# Altium schematic sheet EVK-NORA-W4_SCHEM_0001_Power.SchDoc
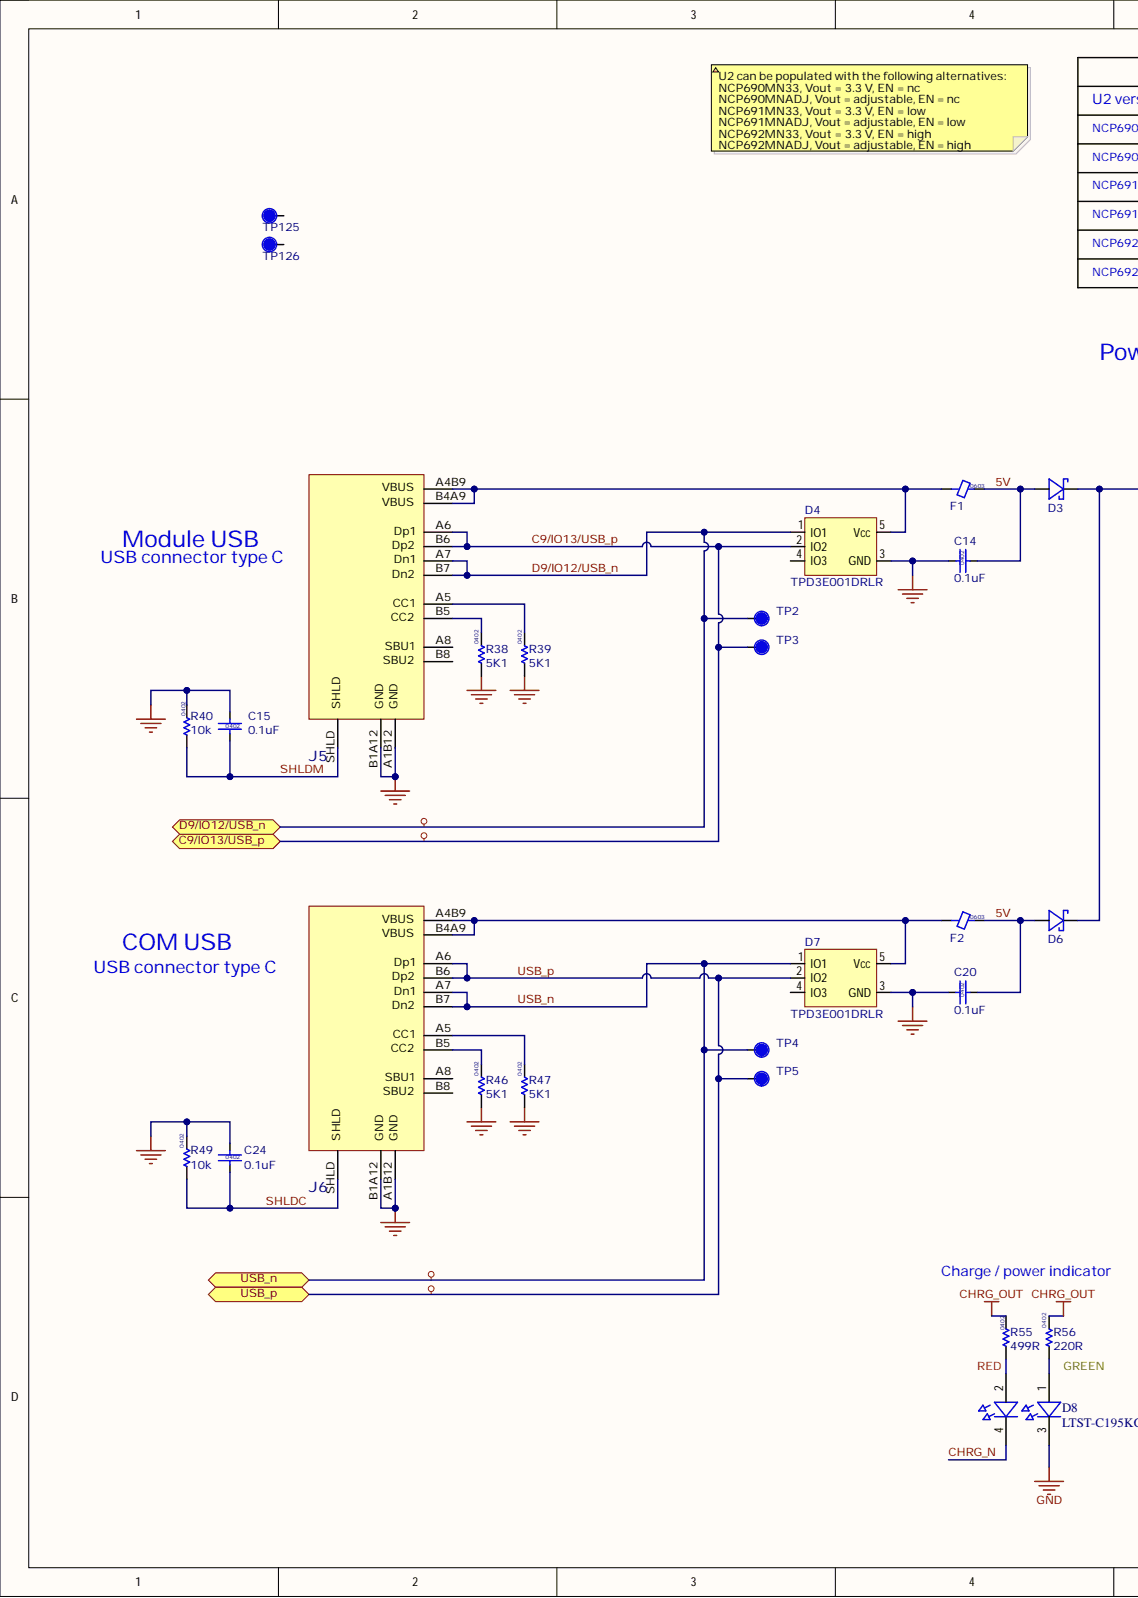
[diagram p0: EVK-NORA-W4_SCHEM_0001_Power.SchDoc, left]
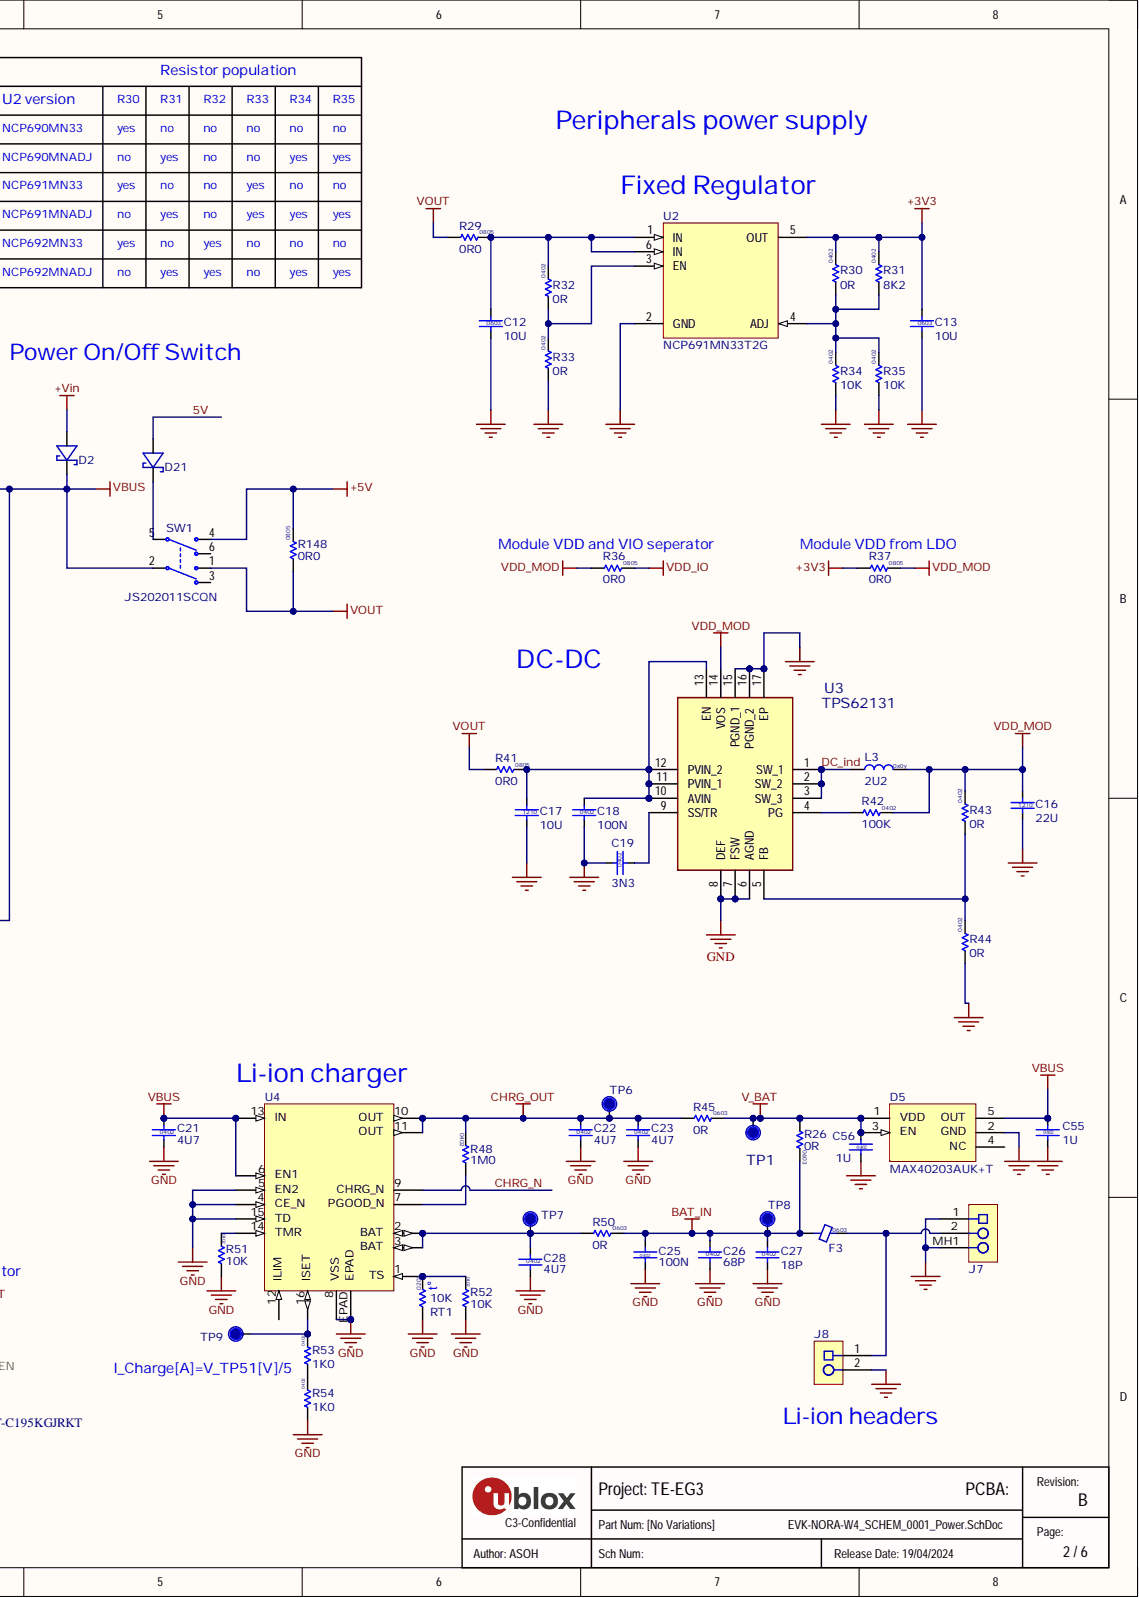
[diagram p1: EVK-NORA-W4_SCHEM_0001_Power.SchDoc, right]

## Parts
C12  value 10U  Size: 0603  [CAP_0603_1750Lx950Wx850H_600x1000Pad_900G_FLX]  (hidden comment: UBXH01-000031010U)
C13  value 10U  Size: 0603  [CAP_0603_1750Lx950Wx850H_600x1000Pad_900G_FLX]  (hidden comment: UBXH01-000031010U)
C14  value 0.1uF  Size: 0402  [Cap 0402 HD]  (hidden comment: 120-000160.1uF)
C15  value 0.1uF  Size: 0402  [Cap 0402 HD]  (hidden comment: 120-000160.1uF)
C16  value 22U  Size: 1210  [CAP_1210_3400Lx2700Wx1350H_900x2700Pad_1900G_FLX]  (hidden comment: UBXH01-300100522U)
C17  value 10U  Size: 1210  [CAP_1210_3400Lx2700Wx1350H_900x2700Pad_1900G_FLX]  (hidden comment: UBXH01-300100410U)
C18  value 100N  Size: 0402  [Cap_0402_1100Lx600Wx600H_600x600Pad_350G_FLX]  (hidden comment: UBXH01-0000204100N)
C19  value 3N3  Size: 0402  [Cap_0402_1100Lx600Wx600H_600x600Pad_350G_FLX]  (hidden comment: UBXH01-00230193N3)
C20  value 0.1uF  Size: 0402  [Cap 0402 HD]  (hidden comment: 120-000160.1uF)
C21  value 4U7  Size: 0402  [Cap 0402 HD]  (hidden comment: UBXH01-00002434U7)
C22  value 4U7  Size: 0402  [Cap 0402 HD]  (hidden comment: UBXH01-00002434U7)
C23  value 4U7  Size: 0402  [Cap 0402 HD]  (hidden comment: UBXH01-00002434U7)
C24  value 0.1uF  Size: 0402  [Cap 0402 HD]  (hidden comment: 120-000160.1uF)
C25  value 100N  Size: 0402  [Cap 0402 HD]  (hidden comment: UBXH01-2021002100N)
C26  value 68P  Size: 0402  [Cap 0402 HD]  (hidden comment: UBXH01-000402468P)
C27  value 18P  Size: 0402  [Cap_0402_1100Lx600Wx600H_600x600Pad_350G_FLX]  (hidden comment: UBXH01-000401718P)
C28  value 4U7  Size: 0402  [Cap 0402 HD]  (hidden comment: UBXH01-00002434U7)
C55  value 1U  Size: 0402  [Cap 0402 HD]  (hidden comment: UBXH01-00002481U)
C56  value 1U  Size: 0402  [Cap 0402 HD]  (hidden comment: UBXH01-00002481U)
D2  110-00005  [SOD-123F_U]  (value not drawn)
D3  110-00005  [SOD-123F_U]  (value not drawn)
D4  Manufacturer P/N: TPD3E001DRLR  [SOT-553]  (hidden comment: 110-00018)
D5  value MAX40203AUK+T  Manufacturer P/N: MAX40203AUK+T  [SOT-23-5_2]  (hidden comment: UBXH12-0000539)
D6  110-00005  [SOD-123F_U]  (value not drawn)
D7  Manufacturer P/N: TPD3E001DRLR  [SOT-553]  (hidden comment: 110-00018)
D8  LTST-C195KGJRKT  [LTSTC195KGJRKT]
D21  110-00005  [SOD-123F_U]  (value not drawn)
F1  Size: 0603  [IND_0603_1750Lx950Wx1050H_600x800Pad_800G_FLX]  (hidden comment: UBXH08-0000001)
F2  Size: 0603  [IND_0603_1750Lx950Wx1050H_600x800Pad_800G_FLX]  (hidden comment: UBXH08-0000001)
F3  Size: 0603  [IND_0603_1750Lx950Wx1050H_600x800Pad_800G_FLX]  (hidden comment: UBXH08-0000001)
J5  USB4105-GF-A  [USB4105-GF-A]  (value not drawn)
J6  USB4105-GF-A  [USB4105-GF-A]  (value not drawn)
J7  UBXH04-0000791  [B2B-PH-SM4-TB]  (value not drawn)
J8  UBXH04-0000026  [HDR-0.1P-2PIN-TH]  (value not drawn)
L3  value 2U2  Size: 0x0y  [IND_0603_1800Lx1000Wx1000H_600x1000Pad_650G_FLX]  (hidden comment: UBXH09-00003302U2)
R26  value 0R  Size: 0603  [RES_0603_1700Lx950Wx600H_600x1000Pad_800G_FLX]  (hidden comment: UBXH18-00030010R)
R29  0R0  Size: 0805  [RES 0805_2150Lx1400Wx650H_800x1300Pad_1000G_FLX]
R30  value 0R  Size: 0402  [RES 0402 HD]  (hidden comment: UBXH18-00030020R)
R31  value 8K2  Size: 0402  [RES_0402_1100Lx600Wx400H_500x600Pad_400G_FLX]  (hidden comment: UBXH18-00001388K2)
R32  value 0R  Size: 0402  [RES 0402 HD]  (hidden comment: UBXH18-00030020R)
R33  value 0R  Size: 0402  [RES 0402 HD]  (hidden comment: UBXH18-00030020R)
R34  value 10K  Size: 0402  [RES 0402 HD]  (hidden comment: UBXH18-000006510K)
R35  value 10K  Size: 0402  [RES 0402 HD]  (hidden comment: UBXH18-000006510K)
R36  0R0  Size: 0805  [RES 0805_2150Lx1400Wx650H_800x1300Pad_1000G_FLX]
R37  0R0  Size: 0805  [RES 0805_2150Lx1400Wx650H_800x1300Pad_1000G_FLX]
R38  value 5K1  Size: 0402  [RES 0402 HD]  (hidden comment: UBXH18-00080665K1)
R39  value 5K1  Size: 0402  [RES 0402 HD]  (hidden comment: UBXH18-00080665K1)
R40  value 10k  Size: 0402  [RES 0402 HD]  (hidden comment: 180-0002410k)
R41  0R0  Size: 0805  [RES 0805_2150Lx1400Wx650H_800x1300Pad_1000G_FLX]
R42  value 100K  Size: 0402  [RES 0402 HD]  (hidden comment: UBXH18-0000005100K)
R43  value 0R  Size: 0402  [RES 0402 HD]  (hidden comment: UBXH18-00030020R)
R44  value 0R  Size: 0402  [RES 0402 HD]  (hidden comment: UBXH18-00030020R)
R45  value 0R  Size: 0603  [RES_0603_1700Lx950Wx600H_600x1000Pad_800G_FLX]  (hidden comment: UBXH18-00030010R)
R46  value 5K1  Size: 0402  [RES 0402 HD]  (hidden comment: UBXH18-00080665K1)
R47  value 5K1  Size: 0402  [RES 0402 HD]  (hidden comment: UBXH18-00080665K1)
R48  value 1M0  Size: 0402  [RES 0402 HD]  (hidden comment: UBXH18-00081211M0)
R49  value 10k  Size: 0402  [RES 0402 HD]  (hidden comment: 180-0002410k)
R50  value 0R  Size: 0603  [RES_0603_1700Lx950Wx600H_600x1000Pad_800G_FLX]  (hidden comment: UBXH18-00030010R)
R51  value 10K  Size: 0402  [RES 0402 HD]  (hidden comment: UBXH18-000006510K)
R52  value 10K  Size: 0402  [RES 0402 HD]  (hidden comment: UBXH18-000006510K)
R53  value 1K0  Size: 0402  [RES 0402 HD]  (hidden comment: UBXH18-00080491K0)
R54  value 1K0  Size: 0402  [RES 0402 HD]  (hidden comment: UBXH18-00080491K0)
R55  value 499R  Size: 0402  [RES 0402 HD]  (hidden comment: UBXH18-0000269499R)
R56  value 220R  Size: 0402  [RES 0402 HD]  (hidden comment: UBXH18-0008033220R)
R148  0R0  Size: 0805  [RES 0805_2150Lx1400Wx650H_800x1300Pad_1000G_FLX]
RT1  value 10K  Size: 0201  [RES 0201]  (hidden comment: Res Thermal)
SW1  value JS202011SCQN  Manufacturer P/N: JS202011SCQN  [SW_Slide_DPDT_JS202011SCQN]  (hidden comment: UBXH19-0000050)
TP1  TP  [TP10R]
TP2  TP  [TP10R]  (no BOM)
TP3  TP  [TP10R]  (no BOM)
TP4  TP  [TP10R]  (no BOM)
TP5  TP  [TP10R]  (no BOM)
TP6  TP  [TP10R]  (no BOM)
TP7  TP  [TP10R]  (no BOM)
TP8  TP  [TP10R]  (no BOM)
TP9  TP  [TP10R]  (no BOM)
TP125  TP  [TP10R]  (no BOM)
TP126  TP  [TP10R]  (no BOM)
U2  NCP691MN33T2G  Part Type: Regulator  [DFN-PSON.1P3X3-7pin_HS-7N]
U3  TPS62131  [QFN50P300X300X100-17N-D]
U4  UBXH05-0000185  [QFN0.5P3x3-16Pin_W-Pad]  (value not drawn)

## Ports
C9/IO13/USB_p, D9/IO12/USB_n, USB_n, USB_p

## Nets
+3V3: C13.1 R30.2 R31.2 R37.1 U2.5(OUT)
+Vin: D2.A
BAT_IN: C25.2 C26.1 C27.2 F3.1 R26.2 R50.2 TP8.1
CHRG_OUT: C22.1 C23.1 R45.1 R48.2 R55.2 R56.2 TP6.1 U4.10(OUT) U4.11(OUT)
GND: C12.2 C13.2 C14.1 C15.1 C16.2 C17.2 C18.2 C19.1 C20.1 C21.2 C22.2 C23.2 C24.1 C25.1 C26.2 C27.1 C28.2 C55.2 C56.2 D4.3(GND) D5.2(GND) D7.3(GND) D8.3 J5.A1B12(GND) J5.B1A12(GND) J6.A1B12(GND) J6.B1A12(GND) J7.1 J7.MH1 J8.2 R33.1 R34.1 R35.1 R38.1 R39.1 R40.2 R44.1 R46.1 R47.1 R49.2 R51.1 R52.1 R54.1 RT1.2 U2.2(GND) U3.6(AGND) U3.7(FSW) U3.8(DEF) U3.15(PGND_1) U3.16(PGND_2) U3.17(EP) U4.4(CE_N) U4.5(EN2) U4.8(VSS) U4.15(TD) U4.EPAD
V_BAT: C56.1 D5.1(VDD) D5.3(EN) R26.1 R45.2 TP1.1
VBUS: C21.1 C55.1 D2.C D3.C D5.5(OUT) D6.C SW1.2 U4.6(EN1) U4.13(IN)
VDD_IO: R36.2
VDD_MOD: C16.1 L3.2 R36.1 R37.2 R42.2 R43.2 U3.14(VOS)
VOUT: R29.1 R41.1 R148.1 SW1.1
5V: C14.2 C20.2 D3.A D6.A D21.A F1.2 F2.2 R148.2 SW1.4
C9/IO13/USB_p: D4.2(IO2) J5.A6(Dp1) J5.B6(Dp2) TP3.1 ; port C9/IO13/USB_p
CHRG_N: D8.4 U4.9(CHRG_N)
D9/IO12/USB_n: D4.1(IO1) J5.A7(Dn1) J5.B7(Dn2) TP2.1 ; port D9/IO12/USB_n
DC_ind: L3.1 U3.1(SW_1) U3.2(SW_2) U3.3(SW_3)
SHLDC: C24.2 J6.SHLD R49.1
SHLDM: C15.2 J5.SHLD R40.1
USB_n: D7.1(IO1) J6.A7(Dn1) J6.B7(Dn2) TP4.1 ; port USB_n
USB_p: D7.2(IO2) J6.A6(Dp1) J6.B6(Dp2) TP5.1 ; port USB_p
NetC12_1: C12.1 R29.2 R32.2 U2.1(IN) U2.6(IN)
NetC17_1: C17.1 C18.1 R41.2 U3.10(AVIN) U3.11(PVIN_1) U3.12(PVIN_2) U3.13(EN)
NetC19_2: C19.2 U3.9(SS/TR)
NetC28_1: C28.1 R50.1 TP7.1 U4.2(BAT) U4.3(BAT)
NetD4_5: D4.5(Vcc) F1.1 J5.A4B9(VBUS) J5.B4A9(VBUS)
NetD7_5: D7.5(Vcc) F2.1 J6.A4B9(VBUS) J6.B4A9(VBUS)
NetD8_1: D8.1 R56.1
NetD8_2: D8.2 R55.1
NetD21_C: D21.C SW1.5
NetF3_2: F3.2 J7.2 J8.1
NetJ5_A5: J5.A5(CC1) R39.2
NetJ5_B5: J5.B5(CC2) R38.2
NetJ6_A5: J6.A5(CC1) R47.2
NetJ6_B5: J6.B5(CC2) R46.2
NetR30_1: R30.1 R31.1 R34.2 R35.2 U2.4(ADJ)
NetR32_1: R32.1 R33.2 U2.3(EN)
NetR42_1: R42.1 U3.4(PG)
NetR43_1: R43.1 R44.2 U3.5(FB)
NetR48_1: R48.1 U4.7(PGOOD_N)
NetR51_2: R51.2 U4.14(TMR)
NetR52_2: R52.2 RT1.1 U4.1(TS)
NetR53_1: R53.1 R54.2
NetR53_2: R53.2 TP9.1 U4.16(ISET)

Not connected: D4 4(IO3); D5 4(NC); D7 4(IO3); J5 A8(SBU1) B8(SBU2); J6 A8(SBU1) B8(SBU2); SW1 3 6; TP125 1; TP126 1; U4 12(ILIM)

## Library data of the parts
C12 C13 = UBXH01-0000310: CAP CER X7R 0603 10U 20% 10V; Manufacturer: Murata Manufacturing Company, Ltd.; Manufacturer P/N: GRM188Z71A106MA73; Database Table Name: Passive - Capacitor; Editor: michael.hein; Env: ROHS; Mfg P/N Released: Yes; Mfg P/N Split: 100; Mfg P/N Status: Active; Perferred: PREF; Permitted Use: Volume Products; Released by: martin.grasse; Component Kind: Standard; Library Name: UBX_Parts_DB_Lib.DbLib; Manufacturer 2: Murata Manufacturing Company, Ltd.; Manufacturer P/N 2: GRM188Z71A106KA73; Max Operating Temp: 125°C; Max Voltage: 10V; Mfg P/N Datasheet: https://wiki.u-blox.com/bin/view/Generic/Docs/DocumentSummary?index=26282; Mfg P/N Datasheet 2: https://wiki.u-blox.com/bin/view/Generic/Docs/DocumentSummary?index=28416; Mfg P/N Released 2: Yes; Mfg P/N Split 2: 0; Mfg P/N Status 2: Active; Min Operating Temp: -55°C; Part Number: UBXH01-0000310; Part Type: Capacitor; RefDes: C; Release Data: 2017-02-09T11:14:05; Signal Integrity: Cap Semi; Simulation: CAP; Tolerance: 20%; UBX Wiki: https://wiki.u-blox.com/bin/view/Operations/PartDetails?index=26280
C14 C15 C20 C24 = 120-00016: Cap, Ceramic 0.1uF 10V 10% X5R 0402; Manufacturer: Murata Electronics North America; Manufacturer P/N: GRM155R61A104KA01J; Part Name: CAP CER 0.1UF 10V 10% X5R 0402; Component Mounting Type: SMT; Database Table Name: Ceramic Capacitor; Item_Phase: Production; Tolerance: +/- 10%; Use in new designs: Yes; Arena Link: https://app.bom.com/a/GYHPV2L2L43KRMBK?v=spec.summary; Component Kind: Standard; Height: 0.55mm; Manufacturer 2: Yageo; Manufacturer P/N 2: CC0402KRX5R6BB104; Part Number: 120-00016; Part_Type: Ceramic Capacitor; Pins: 2; Revision: 0A; Signal Integrity: Cap Semi; Simulation: CAP; Supplier 1: Digi-Key; Supplier 2: Digi-Key; Supplier Part Number 1: 490-6297-1-ND; Supplier Part Number 2: 311-1336-1-ND; Temperature Coefficient: X5R; Voltage: 10V; Library Name: Arena_Parts_DB_Lib.DbLib
C16 = UBXH01-3001005: CAP CER X5R 1210 22U 10% 25V; Manufacturer: Murata Manufacturing Company, Ltd.; Manufacturer P/N: GRM32ER61E226KE15; Database Table Name: Passive - Capacitor; Editor: roland.rust; Env: ROHS; Mfg P/N Released: Yes; Mfg P/N Split: 100; Mfg P/N Status: NRND; Perferred: PREF; Permitted Use: Volume Products; Component Kind: Standard; Library Name: UBX_Parts_DB_Lib.DbLib; Max Voltage: 25V; Part Number: UBXH01-3001005; Part Type: Capacitor; RefDes: C; Release Data: 2009-04-24T15:51:56; Signal Integrity: Cap Semi; Simulation: CAP; Tolerance: 10%; UBX Wiki: https://wiki.u-blox.com/bin/view/Operations/PartDetails?index=5780; Released by: roland.rust
C17 = UBXH01-3001004: CAP CER X5R 1210 10U 10% 25V; Manufacturer: Murata Manufacturing Company, Ltd.; Manufacturer P/N: GRM32DR61E106KA12; Database Table Name: Passive - Capacitor; Editor: andreas.thiel; Env: ROHS; Mfg P/N Released: Yes; Mfg P/N Split: 100; Mfg P/N Status: NRND; Perferred: NONPREF; Permitted Use: Volume Products; Released by: johann.furrer; Component Kind: Standard; Library Name: UBX_Parts_DB_Lib.DbLib; Max Voltage: 25V; Mfg P/N Datasheet: https://wiki.u-blox.com/bin/view/Generic/Docs/DocumentSummary?index=8644; Part Number: UBXH01-3001004; Part Type: Capacitor; RefDes: C; Release Data: 2010-04-01T16:00:35; Signal Integrity: Cap Semi; Simulation: CAP; Tolerance: 10%; UBX Wiki: https://wiki.u-blox.com/bin/view/Operations/PartDetails?index=5778
C18 = UBXH01-0000204: CAP CER X5R 0402 100N 10% 50V; Manufacturer: Murata Manufacturing Company, Ltd.; Manufacturer P/N: GRM155R61H104KE14D; Database Table Name: Passive - Capacitor; Editor: matej.budin; Env: ROHS; Mfg P/N Released: Yes; Mfg P/N Split: 100; Mfg P/N Status: Active; Perferred: PREF; Permitted Use: Support Products; Released by: erian.bezhani; Component Kind: Standard; Library Name: UBX_Parts_DB_Lib.DbLib; Max Voltage: 50V; Mfg P/N Datasheet: https://wiki.u-blox.com/bin/view/Generic/Docs/DocumentSummary?index=22969; Part Number: UBXH01-0000204; Part Type: Capacitor; RefDes: C; Release Data: 2015-08-06T11:12:34; Signal Integrity: Cap Semi; Simulation: CAP; Tolerance: 10%; UBX Wiki: https://wiki.u-blox.com/bin/view/Operations/PartDetails?index=22967
C19 = UBXH01-0023019: CAP CER X7R 0402 3N3 10% 16V; Manufacturer: Murata Manufacturing Company, Ltd.; Manufacturer P/N: GRM155R71H332KA01; Database Table Name: Passive - Capacitor; Editor: dario.antonaz; Env: ROHS; Mfg P/N Released: Yes; Mfg P/N Split: 100; Mfg P/N Status: NRND; Perferred: PREF; Permitted Use: Volume Products; Released by: pierpaolo.arban; Component Kind: Standard; Library Name: UBX_Parts_DB_Lib.DbLib; Max Voltage: 16V; Mfg P/N Datasheet: https://wiki.u-blox.com/bin/view/Generic/Docs/DocumentSummary?index=19430; Part Number: UBXH01-0023019; Part Type: Capacitor; RefDes: C; Release Data: 2013-08-21T12:21:41; Signal Integrity: Cap Semi; Simulation: CAP; Tolerance: 10%; UBX Wiki: https://wiki.u-blox.com/bin/view/Operations/PartDetails?index=5479
C21-C23 C28 = UBXH01-0000243: CAP CER X5R 0402 4U7 20% 10V; Manufacturer: Murata Manufacturing Company, Ltd.; Manufacturer P/N: GRM155R61A475MEAA; Database Table Name: Passive - Capacitor; Editor: martin.pilik; Env: GREEN; Mfg P/N Released: Yes; Mfg P/N Split: 100; Mfg P/N Status: Active; Perferred: PREF; Permitted Use: Volume Products; Released by: fabrice.bret-dibat; Component Kind: Standard; Library Name: UBX_Parts_DB_Lib.DbLib; Max Operating Temp: 85°C; Max Voltage: 10V; Mfg P/N Datasheet: https://wiki.u-blox.com/bin/view/Generic/Docs/DocumentSummary?index=24302; Min Operating Temp: -40°C; Part Number: UBXH01-0000243; Part Type: Capacitor; RefDes: C; Release Data: 2021-05-10T12:57:47; Signal Integrity: Cap Semi; Simulation: CAP; Tolerance: 20%; UBX Wiki: https://wiki.u-blox.com/bin/view/Operations/PartDetails?index=24303
C25 = UBXH01-2021002: CAP CER X5R 0402 100N 10% 10V; Manufacturer: Murata Manufacturing Company, Ltd.; Manufacturer P/N: GRM155R61A104KA01; Database Table Name: Passive - Capacitor; Editor: roland.rust; Env: GREEN; Mfg P/N Released: Yes; Mfg P/N Split: 100; Mfg P/N Status: NRND; Perferred: PREF; Permitted Use: Volume Products; Component Kind: Standard; Library Name: UBX_Parts_DB_Lib.DbLib; Manufacturer 2: Samsung Electro-Mechanics; Manufacturer P/N 2: CL05A104KP5NNN; Max Voltage: 10V; Mfg P/N Datasheet 2: https://wiki.u-blox.com/bin/view/Generic/Docs/DocumentSummary?index=28113; Mfg P/N Released 2: Yes; Mfg P/N Split 2: 0; Mfg P/N Status 2: Active; Part Number: UBXH01-2021002; Part Type: Capacitor; RefDes: C; Release Data: 2005-07-22T13:59:44; Signal Integrity: Cap Semi; Simulation: CAP; Tolerance: 10%; UBX Wiki: https://wiki.u-blox.com/bin/view/Operations/PartDetails?index=5759; Released by: roland.rust
C26 = UBXH01-0004024: CAP CER C0G 0402 68P 5% 25V; Manufacturer: Murata Manufacturing Company, Ltd.; Manufacturer P/N: GRM1555C1H680JA01; Database Table Name: Passive - Capacitor; Editor: martin.frauchiger; Env: ROHS; Mfg P/N Released: Yes; Mfg P/N Split: 100; Mfg P/N Status: NRND; Perferred: PREF; Permitted Use: Volume Products; Component Kind: Standard; Library Name: UBX_Parts_DB_Lib.DbLib; Manufacturer 2: Murata Manufacturing Company, Ltd.; Manufacturer 3: Murata Manufacturing Company, Ltd.; Manufacturer P/N 2: GRM1555C1E680F; Manufacturer P/N 3: GRM1555C1H680F; Max Voltage: 25V; Mfg P/N Datasheet: https://wiki.u-blox.com/bin/view/Generic/Docs/DocumentSummary?index=19268; Mfg P/N Released 2: Yes; Mfg P/N Released 3: Yes; Mfg P/N Split 2: 0; Mfg P/N Split 3: 0; Mfg P/N Status 2: NRND; Mfg P/N Status 3: NRND; Part Number: UBXH01-0004024; Part Type: Capacitor; RefDes: C; Release Data: 2005-09-01T15:36:53; Signal Integrity: Cap Semi; Simulation: CAP; Tolerance: 5%; UBX Wiki: https://wiki.u-blox.com/bin/view/Operations/PartDetails?index=5199; Released by: martin.frauchiger
C27 = UBXH01-0004017: CAP CER C0G 0402 18P 5% 25V; Manufacturer: Murata Manufacturing Company, Ltd.; Manufacturer P/N: GRM1555C1H180JA01; Database Table Name: Passive - Capacitor; Editor: doris.zahnd; Env: ROHS; Mfg P/N Released: Yes; Mfg P/N Split: 100; Mfg P/N Status: NRND; Perferred: PREF; Permitted Use: Volume Products; Released by: daniel.hurni; Component Kind: Standard; Library Name: UBX_Parts_DB_Lib.DbLib; Manufacturer 2: Murata Manufacturing Company, Ltd.; Manufacturer 3: Murata Manufacturing Company, Ltd.; Manufacturer P/N 2: GRM1555C1E180JZ01; Manufacturer P/N 3: GRM1555C1H180JZ01; Max Operating Temp: 125°C; Max Voltage: 25V; Mfg P/N Released 2: Yes; Mfg P/N Released 3: Yes; Mfg P/N Split 2: 0; Mfg P/N Split 3: 0; Mfg P/N Status 2: Obsolete; Mfg P/N Status 3: Obsolete; Min Operating Temp: -55°C; Part Number: UBXH01-0004017; Part Type: Capacitor; RefDes: C; Release Data: 2009-12-03T17:48:42; Signal Integrity: Cap Semi; Simulation: CAP; Tolerance: 5%; UBX Wiki: https://wiki.u-blox.com/bin/view/Operations/PartDetails?index=5178
C55 C56 = UBXH01-0000248: CAP CER X5R 0402 1U 10% 10V; Manufacturer: Murata Manufacturing Company, Ltd.; Manufacturer P/N: GRM155R61C105KA12; Database Table Name: Passive - Capacitor; Editor: michael.hein; Env: ROHS; Mfg P/N Released: Yes; Mfg P/N Split: 100; Mfg P/N Status: Active; Perferred: PREF; Permitted Use: Volume Products; Released by: igor.shevchenko; Component Kind: Standard; Library Name: UBX_Parts_DB_Lib.DbLib; Manufacturer 2: TDK Corporation; Manufacturer 3: Samsung Electro-Mechanics; Manufacturer P/N 2: C1005X5R1E105K050BC; Manufacturer P/N 3: CL05A105KA5NQNC; Max Operating Temp: 85°C; Max Voltage: 10V; Mfg P/N Datasheet: https://wiki.u-blox.com/bin/view/Generic/Docs/DocumentSummary?index=29581; Mfg P/N Datasheet 2: https://wiki.u-blox.com/bin/view/Generic/Docs/DocumentSummary?index=28058; Mfg P/N Datasheet 3: https://wiki.u-blox.com/bin/view/Generic/Docs/DocumentSummary?index=28056; Mfg P/N Released 2: Yes; Mfg P/N Released 3: Yes; Mfg P/N Split 2: 0; Mfg P/N Split 3: 0; Mfg P/N Status 2: Active; Mfg P/N Status 3: Active; Min Operating Temp: -40°C; Part Number: UBXH01-0000248; Part Type: Capacitor; RefDes: C; Release Data: 2016-03-16T11:26:35; Signal Integrity: Cap Semi; Simulation: CAP; Tolerance: 10%; UBX Wiki: https://wiki.u-blox.com/bin/view/Operations/PartDetails?index=24378
D2 D3 D6 D21 = 110-00005: Diode, Schottky, 20V 1A SOD-123F, 340mV Vf @ 1A MBR120VLSFT3G; Manufacturer 1: ON Semiconductor; Part Name: Diode Schottky 20V 1A SOD-123FL; Body Size: None; Item Phase: In Production; MFG Package: SOD-123FL; Use in new designs: Yes; Component Kind: Standard; Current: 1A; Database Table Name: Diode; Height: 0.98mm; Manufacturer 2: None; Manufacturer Part Number 1: MBR120VLSFT3G; Manufacturer Part Number 2: None; Max Operating Temp: 125; Min Operating Temp: -65; Part Number: 110-00005; Pins: 2; Revision: 0B; Supplier 1: Digi-Key; Supplier 2: None; Supplier Part Number 1: MBR120VLSFT3GOSCT-ND; Supplier Part Number 2: None; UBX Code: None; Voltage: 20V; Library Name: Arena_Parts_DB_Lib.DbLib
D4 D7 = 110-00018: LOW-CAPACITANCE 3-CHANNEL ESD-PROTECTION ARRAY; Manufacturer: Texas Instruments; Part Name: TVS Diode, 3CH High Speed ESD; Body Size: 1.6mm x 1.2mm; Component Mounting Type: SMT; Database Table Name: Diode; Diode Type: TVS; Item_Phase: Production; Use in new designs: Yes; Voltage: 5V; Arena Link: https://app.bom.com/a/UCV39GZGZIHX50AE?v=spec.summary; Component Kind: Standard; Height: .55mm; MFG Package: SOT-553; Package Type: SOT; Part Number: 110-00018; Part_Type: Diode; Pins: 5; Revision: 0A; Supplier 1: Digi-Key; Supplier Part Number 1: 296-21885-1-ND; Library Name: Arena_Parts_DB_Lib.DbLib
D5 = UBXH12-0000539: IDEAL DIODE OR CONTROLLER SOURCE SELECTOR SWITCH P-CHANNEL 1:1 SOT-23-5 5.5V 1A; Manufacturer: Maxim; Part Name: IC IDEAL DIODE LDO 1.2-5.5V 1.0A SOT-23-5; Body Size: 2.8mm x 2.9mm; Component Mounting Type: SMT; Current: 1.0A; Database Table Name: IC - PMIC; Editor: eric.moog; Env: ROHS; Item_Phase: Preliminary; Mfg P/N Released: No; Mfg P/N Split: 100; Mfg P/N Status: Active; Permitted Use: Support Products; SMT Pitch: 0.95mm; Use in new designs: Yes; Component Kind: Standard; Height: 1.25; HelpURL: https://datasheets.maximintegrated.com/en/ds/MAX40203.pdf; Library Name: UBX_Parts_DB_Lib.DbLib; Manufacturer 1: Maxim; Manufacturer Part Number 1: MAX40203AUK+T; Max Current: 1A; Max Voltage: 5.5V; Mfg P/N Datasheet: https://wiki.u-blox.com/bin/view/Generic/Docs/DocumentSummary?index=38598; MFG Package: SOT-23-5; Package Type: SOT-23-5; Part Number: UBXH12-0000539; Part Type: PMIC; Part_Type: Ideal Diode; Perferred: N/A; Pins: 5; RefDes: U; Supplier 1: Digi-Key; Supplier Part Number 1: 175-MAX40203AUK+TTR-ND; UBX Wiki: https://wiki.u-blox.com/bin/view/Operations/PartDetails?index=38597; Voltage: 5.5V
D8 = LTST-C195KGJRKT: LED; Manufacturer_Name: Lite-On; Arrow Part Number: LTST-C195KGJRKT; Arrow Price/Stock: https://www.arrow.com/en/products/ltst-c195kgjrkt/lite-on-technology?region=nac; Component Kind: Standard; Datasheet Link: https://mm.digikey.com/Volume0/opasdata/d220001/medias/docus/1134/LTST-C195KGJRKT.pdf; Height: 0.65mm; Library Name: SamacSys.SchLib; Manufacturer_Part_Number: LTST-C195KGJRKT; Mouser Part Number: 859-LTST-C195KGJRKT; Mouser Price/Stock: https://www.mouser.co.uk/ProductDetail/Lite-On/LTST-C195KGJRKT?qs=ohURClPaJWCkP7ius%252BRMYA%3D%3D
F1-F3 = UBXH08-0000001: FERRITE BEAD 0603 600 OHM AT 100MHZ 600OHM 500mA; Manufacturer: TDK Corporation; Manufacturer P/N: MMZ1608B601C; Database Table Name: Passive - Ferrite; Editor: daniel.hurni; Env: ROHS; Mfg P/N Released: Yes; Mfg P/N Split: 100; Mfg P/N Status: Active; Perferred: PREF; Permitted Use: Support Products; Released by: martin.frauchiger; Component Kind: Standard; Library Name: UBX_Parts_DB_Lib.DbLib; Max Current: 500mA; Max Operating Temp: 125°C; Mfg P/N Datasheet: https://wiki.u-blox.com/bin/view/Generic/Docs/DocumentSummary?index=7941; Min Operating Temp: -40°C; Part Number: UBXH08-0000001; Part Type: Ferrite; RefDes: FB; Release Data: 2009-12-02T10:18:36; UBX Wiki: https://wiki.u-blox.com/bin/view/Operations/PartDetails?index=7960; Value: 600OHM
J5 J6 = UBXH04-0000785: CONN RCP USB2.0 TYP C 24P SMD RA 20V 6.25A; Manufacturer: GCT; Body Size: 7.3mm x 8.9mm; Database Table Name: Electromechanical - Connector; Editor: eric.moog; Env: ROHS; Item Phase: Unreleased; Mfg P/N Released: No; Mfg P/N Split: 100; Mfg P/N Status: Active; Perferred: PREF; Permitted Use: Support Products; SMT Pitch: 0.5mm; UBX Code: None; Use in new designs: Yes; Voltage: <20V DC; Component Kind: Standard; Height: 3.31mm; Library Name: UBX_Parts_DB_Lib.DbLib; Manufacturer 1: GCT; Manufacturer 2: None; Manufacturer P/N: USB4105-GF-A; Manufacturer Part Number 1: USB4105-GF-A; Manufacturer Part Number 2: None; Max Current: 6.25A; Max Operating Temp: 85°C; Max Voltage: 20V; Mfg P/N Datasheet: https://wiki.u-blox.com/bin/view/Generic/Docs/DocumentSummary?index=38208; Min Operating Temp: -40°C; Part Number: UBXH04-0000785; Part Type: Connector; Pins: 8; RefDes: J; Revision: None; Size: Other; Supplier 2: None; Supplier Part Number 2: None; UBX Wiki: https://wiki.u-blox.com/bin/view/Operations/PartDetails?index=38207; Value: USB4105-GF-A
J7 = UBXH04-0000791: CONN 1-ROW SMT 2.0MM GRID 2-PIN VERT 100V 2A; Manufacturer: JST; Part Name: Conn, SMT, 0.079" Pitch, 2 Pin, Vert, Black; Database Table Name: Electromechanical - Connector; Editor: eric.moog; Env: ROHS; Item Phase: In Production; Mfg P/N Released: No; Mfg P/N Split: 100; Mfg P/N Status: Active; Permitted Use: Support Products; UBX Code: None; Use in new designs: Yes; Value: B2B-PH-SM4-TB; Component Kind: Standard; Diameter: None; Height: 6.5mm; Library Name: UBX_Parts_DB_Lib.DbLib; Manufacturer 2: None; Manufacturer P/N: B2B-PH-SM4-TB; Manufacturer Part Number 1: B2B-PH-SM4-TB; Manufacturer Part Number 2: None; Max Current: 2A; Max Operating Temp: 85°C; Max Voltage: 100V; Mfg P/N Datasheet: https://wiki.u-blox.com/bin/view/Generic/Docs/DocumentSummary?index=35463; Min Operating Temp: -30°C; Part Number: UBXH04-0000791; Part Type: Connector; Perferred: N/A; Pins: 2; RefDes: J; Revision: 0A; Supplier 1: None; Supplier 2: None; Supplier Part Number 1: None; Supplier Part Number 2: None; UBX Wiki: https://wiki.u-blox.com/bin/view/Operations/PartDetails?index=38567; Voltage: None
J8 = UBXH04-0000026: 1-ROW TH-PCB SOCKETS 100MIL GRID 2-PIN 0.64MM SQUARE POLARIZE; Manufacturer: HARWiN; Manufacturer P/N: M20-9990245; Database Table Name: Electromechanical - Connector; Editor: roland.rust; Env: ROHS; Mfg P/N Released: Yes; Mfg P/N Split: 100; Mfg P/N Status: Active; Perferred: PREF; Permitted Use: Internal Use; Released by: alvaro.nussbaum; Value: M20-9990245; Component Kind: Standard; Library Name: UBX_Parts_DB_Lib.DbLib; Part Number: UBXH04-0000026; Part Type: Connector; RefDes: J; Release Data: 2015-06-04T16:45:09; UBX Wiki: https://wiki.u-blox.com/bin/view/Operations/PartDetails?index=10937
L3 = UBXH09-0000330: IND TAIYOYUDEN MCHK1608T 2U2 20% 1.5A; Manufacturer: Taiyo Yuden Company, Ltd.; Manufacturer P/N: MCHK1608T2R2MKN; Database Table Name: Passive - Inductor; Editor: martin.grasse; Env: ROHS; Mfg P/N Released: Yes; Mfg P/N Split: 100; Mfg P/N Status: Active; Perferred: PREF; Permitted Use: Volume Products; Released by: igor.shevchenko; Component Kind: Standard; Library Name: UBX_Parts_DB_Lib.DbLib; Manufacturer 2: Taiyo Yuden Company, Ltd.; Manufacturer 3: Taiyo Yuden Company, Ltd.; Manufacturer P/N 2: LSCNB1608HKT2R2MD; Manufacturer P/N 3: LLCNB1608HKT2R2MD; Max Current: 1.5A; Max Operating Temp: 125°C; Mfg P/N Datasheet: https://wiki.u-blox.com/bin/view/Generic/Docs/DocumentSummary?index=32940; Mfg P/N Datasheet 2: https://wiki.u-blox.com/bin/view/Generic/Docs/DocumentSummary?index=39018; Mfg P/N Datasheet 3: https://wiki.u-blox.com/bin/view/Generic/Docs/DocumentSummary?index=39017; Mfg P/N Released 2: Yes; Mfg P/N Released 3: Yes; Mfg P/N Split 2: 0; Mfg P/N Split 3: 0; Mfg P/N Status 2: Active; Mfg P/N Status 3: Active; Min Operating Temp: -40°C; Part Number: UBXH09-0000330; Part Type: Inductor; RefDes: L; Release Data: 2021-01-25T16:52:34; Tolerance: 20%; UBX Wiki: https://wiki.u-blox.com/bin/view/Operations/PartDetails?index=32938
R26 R45 R50 = UBXH18-0003001: RES THICK FILM CHIP 0603 0R 0 100mW; Manufacturer: YAGEO; Manufacturer P/N: RC0603JR-070RL; Database Table Name: Passive - Resistor; Editor: martin.frauchiger; Env: ROHS; Mfg P/N Released: Yes; Mfg P/N Split: 100; Mfg P/N Status: Active; Perferred: PREF; Permitted Use: Volume Products; Tolerance: 0; Component Kind: Standard; Library Name: UBX_Parts_DB_Lib.DbLib; Max Power: 100mW; Part Number: UBXH18-0003001; Part Type: Resistor; RefDes: R; Release Data: 2005-07-14T10:55:51; Signal Integrity: Res; Simulation: RESISTOR; UBX Wiki: https://wiki.u-blox.com/bin/view/Operations/PartDetails?index=6609; Released by: martin.frauchiger
R29 R36 R37 R41 R148 = UBXH18-0000000: RES THICK FILM CHIP 0805 0R015 1% 330mW; Manufacturer: KOA Speer Electronics, Inc.; Manufacturer P/N: RK73Z2ATTDD; Database Table Name: Passive - Resistor; Editor: roland.rust; Env: ROHS; Mfg P/N Released: No; Mfg P/N Split: 100; Mfg P/N Status: Active; Perferred: PREF; Permitted Use: Internal Use; Released by: frank.felbier; Component Kind: Standard; Library Name: UBX_Parts_DB_Lib.DbLib; Max Operating Temp: 155°C; Max Power: 330mW; Min Operating Temp: -40°C; Part Type: Resistor; RefDes: R; Release Data: 2009-12-08T13:02:51; Signal Integrity: Res; Simulation: RESISTOR; Tolerance: 1%; Value: 0R0
R30 R32 R43 R44 = UBXH18-0003002: RES THICK FILM CHIP 0402 0R 0 100mW; Manufacturer: KOA; Manufacturer P/N: RK73Z1ETTP; Database Table Name: Passive - Resistor; Editor: charlotte.biber; Env: ROHS; Mfg P/N Released: Yes; Mfg P/N Split: 100; Mfg P/N Status: NRND; Perferred: PREF; Permitted Use: Volume Products; Released by: peter.mueller; Tolerance: 0; Component Kind: Standard; Library Name: UBX_Parts_DB_Lib.DbLib; Manufacturer 2: YAGEO; Manufacturer P/N 2: 2322_705_91001_L; Max Power: 100mW; Mfg P/N Released 2: Yes; Mfg P/N Split 2: 0; Mfg P/N Status 2: NRND; Part Number: UBXH18-0003002; Part Type: Resistor; RefDes: R; Release Data: 2012-06-05 09:49:55.978616; Signal Integrity: Res; Simulation: RESISTOR; UBX Wiki: https://wiki.u-blox.com/bin/view/Operations/PartDetails?index=6611
R31 = UBXH18-0000138: RES THICK FILM CHIP 0402 8K2 1% 63mW; Manufacturer: Vishay; Manufacturer P/N: CRCW04028K20FKED; Database Table Name: Passive - Resistor; Editor: matej.budin; Env: ROHS; Mfg P/N Released: Yes; Mfg P/N Split: 100; Mfg P/N Status: Active; Perferred: PREF; Permitted Use: Support Products; Released by: pasquale.scorca; Component Kind: Standard; Library Name: UBX_Parts_DB_Lib.DbLib; Max Operating Temp: 125°C; Max Power: 63mW; Mfg P/N Datasheet: https://wiki.u-blox.com/bin/view/Generic/Docs/DocumentSummary?index=21077; Min Operating Temp: -55°C; Part Number: UBXH18-0000138; Part Type: Resistor; RefDes: R; Release Data: 2014-08-26T11:33:33; Signal Integrity: Res; Simulation: RESISTOR; Tolerance: 1%; UBX Wiki: https://wiki.u-blox.com/bin/view/Operations/PartDetails?index=21078
R33 = UBXH18-0003002: RES THICK FILM CHIP 0402 0R 0 100mW; Manufacturer: KOA; Manufacturer P/N: RK73Z1ETTD; Database Table Name: Passive - Resistor; Editor: charlotte.biber; Env: ROHS; Mfg P/N Released: Yes; Mfg P/N Split: 100; Mfg P/N Status: NRND; Perferred: PREF; Permitted Use: Volume Products; Released by: peter.mueller; Tolerance: 0; Component Kind: Standard; Library Name: UBX_Parts_DB_Lib.DbLib; Manufacturer 2: YAGEO; Manufacturer P/N 2: 2322_705_91001_L; Max Power: 100mW; Mfg P/N Released 2: Yes; Mfg P/N Split 2: 0; Mfg P/N Status 2: Obsolete; Part Number: UBXH18-0003002; Part Type: Resistor; RefDes: R; Release Data: 2012-06-05T09:49:55; Signal Integrity: Res; Simulation: RESISTOR; UBX Wiki: https://wiki.u-blox.com/bin/view/Operations/PartDetails?index=6611
R34 R35 R51 R52 = UBXH18-0000065: RES THICK FILM CHIP 0402 10K 1% 63mW; Manufacturer: KOA; Manufacturer P/N: RK73H1ETTP1002F; Database Table Name: Passive - Resistor; Editor: erian.bezhani; Env: ROHS; Mfg P/N Released: Yes; Mfg P/N Split: 100; Mfg P/N Status: Active; Perferred: PREF; Permitted Use: Volume Products; Released by: massimo.vlacci; Component Kind: Standard; Library Name: UBX_Parts_DB_Lib.DbLib; Max Power: 63mW; Mfg P/N Datasheet: https://wiki.u-blox.com/bin/view/Generic/Docs/DocumentSummary?index=8222; Part Number: UBXH18-0000065; Part Type: Resistor; RefDes: R; Signal Integrity: Res; Simulation: RESISTOR; Tolerance: 1%; UBX Wiki: https://wiki.u-blox.com/bin/view/Operations/PartDetails?index=16837
  R34 R35: Release Data: 2012-06-08 18:02:21.521517
  R51 R52: Release Data: 2012-06-08T18:02:21
R38 R39 R46 R47 = UBXH18-0008066: RES THICK FILM CHIP 0402 5K1 5% 100mW; Manufacturer: Panasonic; Manufacturer P/N: ERJ-2GEJ512X; Database Table Name: Passive - Resistor; Editor: alvaro.nussbaum; Env: ROHS; Mfg P/N Released: No; Mfg P/N Split: 100; Mfg P/N Status: Active; Perferred: NONPREF; Permitted Use: Internal Use; Released by: roland.rust; Component Kind: Standard; Library Name: UBX_Parts_DB_Lib.DbLib; Max Power: 100mW; Part Number: UBXH18-0008066; Part Type: Resistor; RefDes: R; Release Data: 2016-02-11T15:22:01; Signal Integrity: Res; Simulation: RESISTOR; Tolerance: 5%; UBX Wiki: https://wiki.u-blox.com/bin/view/Operations/PartDetails?index=6972
R40 R49 = 180-00024: Resistor 10k ohm 1% 1/16W, 0402; Manufacturer 1: Samsung Electro-Mechanics America, Inc; Manufacturer Part Number 1: RC1005F103CS; Part Name: RES SMD 10K OHM 1% 1/16W 0402; Item Phase: In Production; Tolerance: +/- 1%; UBX Code: None; Use in new designs: Yes; Component Kind: Standard; Database Table Name: Resistor; Height: 0.4mm; Manufacturer 2: Hong Kong Resistors Manufactory; Manufacturer Part Number 2: RCT0210KFLF; Max Operating Temp: 155; Min Operating Temp: -55; Part Number: 180-00024; Pins: 2; Power: 1/16W; Revision: 0E; Signal Integrity: Res; Simulation: RESISTOR; Supplier 1: Digi-Key; Supplier 2: None; Supplier Part Number 1: 1276-3431-1-ND; Supplier Part Number 2: None; Voltage: N/A; Library Name: Arena_Parts_DB_Lib.DbLib
R42 = UBXH18-0000005: RES THICK FILM CHIP 0402 100K 1% 63mW; Manufacturer: KOA; Manufacturer P/N: RK73H1ETTP1003F; Database Table Name: Passive - Resistor; Editor: dario.antonaz; Env: ROHS; Mfg P/N Released: Yes; Mfg P/N Split: 100; Mfg P/N Status: Active; Permitted Use: Volume Products; Released by: sandro.sestan; Component Kind: Standard; Library Name: UBX_Parts_DB_Lib.DbLib; Manufacturer 2: ROHM; Manufacturer P/N 2: MCR01MZPF1003; Max Power: 63mW; Mfg P/N Datasheet: https://wiki.u-blox.com/bin/view/Generic/Docs/DocumentSummary?index=8222; Mfg P/N Datasheet 2: https://wiki.u-blox.com/bin/view/Generic/Docs/DocumentSummary?index=8186; Mfg P/N Released 2: Yes; Mfg P/N Split 2: 0; Mfg P/N Status 2: Obsolete; Part Number: UBXH18-0000005; Part Type: Resistor; Perferred: N/A; RefDes: R; Release Data: 2009-11-30T11:05:22; Signal Integrity: Res; Simulation: RESISTOR; Tolerance: 1%; UBX Wiki: https://wiki.u-blox.com/bin/view/Operations/PartDetails?index=8114
R48 = UBXH18-0008121: RES THICK FILM CHIP 0402 1M0 5% 63mW; Manufacturer: KOA; Manufacturer P/N: RK73B1ETTP105J; Database Table Name: Passive - Resistor; Editor: daniel.hurni; Env: ROHS; Mfg P/N Released: Yes; Mfg P/N Split: 100; Mfg P/N Status: Active; Perferred: PREF; Permitted Use: Volume Products; Released by: doris.zahnd; Component Kind: Standard; Library Name: UBX_Parts_DB_Lib.DbLib; Max Operating Temp: 125°C; Max Power: 63mW; Mfg P/N Datasheet: https://wiki.u-blox.com/bin/view/Generic/Docs/DocumentSummary?index=4543; Min Operating Temp: -55°C; Part Number: UBXH18-0008121; Part Type: Resistor; RefDes: R; Release Data: 2009-12-01T17:35:03; Signal Integrity: Res; Simulation: RESISTOR; Tolerance: 5%; UBX Wiki: https://wiki.u-blox.com/bin/view/Operations/PartDetails?index=7052
R53 R54 = UBXH18-0008049: RES THICK FILM CHIP 0402 1K0 5% 100mW; Manufacturer: YAGEO; Manufacturer P/N: 2322_705_70102_L; Database Table Name: Passive - Resistor; Editor: roland.rust; Env: ROHS; Mfg P/N Released: Yes; Mfg P/N Split: 100; Mfg P/N Status: NRND; Perferred: PREF; Permitted Use: Volume Products; Component Kind: Standard; Library Name: UBX_Parts_DB_Lib.DbLib; Manufacturer 2: KOA; Manufacturer P/N 2: RK73B1ETTD102J; Max Power: 100mW; Mfg P/N Released 2: Yes; Mfg P/N Split 2: 0; Mfg P/N Status 2: NRND; Part Number: UBXH18-0008049; Part Type: Resistor; RefDes: R; Release Data: 2005-07-22T13:59:44; Signal Integrity: Res; Simulation: RESISTOR; Tolerance: 5%; UBX Wiki: https://wiki.u-blox.com/bin/view/Operations/PartDetails?index=6939; Released by: roland.rust
R55 = UBXH18-0000269: RES THICK FILM CHIP 0402 499R 1% 100mW; Manufacturer: KOA; Manufacturer P/N: RK73H1ETTP4990F; Database Table Name: Passive - Resistor; Editor: pierpaolo.arban; Env: ROHS; Mfg P/N Released: No; Mfg P/N Split: 100; Mfg P/N Status: Active; Perferred: PREF; Permitted Use: Volume Products; Component Kind: Standard; Library Name: UBX_Parts_DB_Lib.DbLib; Max Operating Temp: 125°C; Max Power: 100mW; Mfg P/N Datasheet: https://wiki.u-blox.com/bin/view/Generic/Docs/DocumentSummary?index=8222; Min Operating Temp: -55°C; Part Number: UBXH18-0000269; Part Type: Resistor; RefDes: R; Signal Integrity: Res; Simulation: RESISTOR; Tolerance: 1%; UBX Wiki: https://wiki.u-blox.com/bin/view/Operations/PartDetails?index=25384
R56 = UBXH18-0008033: RES THICK FILM CHIP 0402 220R 5% 100mW; Manufacturer: ROHM; Manufacturer P/N: MCR01MZPJ221; Database Table Name: Passive - Resistor; Editor: roland.rust; Env: ROHS; Mfg P/N Released: Yes; Mfg P/N Split: 100; Mfg P/N Status: Active; Perferred: PREF; Permitted Use: Volume Products; Component Kind: Standard; Library Name: UBX_Parts_DB_Lib.DbLib; Manufacturer 2: KOA; Manufacturer 3: YAGEO; Manufacturer P/N 2: RK73B1ETTP221J; Manufacturer P/N 3: 2322_705_87221_L; Max Power: 100mW; Mfg P/N Released 2: Yes; Mfg P/N Released 3: Yes; Mfg P/N Split 2: 0; Mfg P/N Split 3: 0; Mfg P/N Status 2: Active; Mfg P/N Status 3: NRND; Part Number: UBXH18-0008033; Part Type: Resistor; RefDes: R; Release Data: 2009-04-24T14:36:39; Signal Integrity: Res; Simulation: RESISTOR; Tolerance: 5%; UBX Wiki: https://wiki.u-blox.com/bin/view/Operations/PartDetails?index=6914; Released by: roland.rust
RT1 = UBXH18-0000573: THERMISTOR NTC 0201 10K 5% 100mW; Manufacturer: Murata Manufacturing Company, Ltd.; Manufacturer P/N: NCP03XH103J05RL; Database Table Name: Passive - Thermistor; Editor: eric.moog; Env: ROHS; Mfg P/N Released: No; Mfg P/N Split: 100; Mfg P/N Status: Active; Perferred: PREF; Permitted Use: Volume Products; Component Kind: Standard; Library Name: UBX_Parts_DB_Lib.DbLib; Max Operating Temp: 125°C; Max Power: 100mW; Mfg P/N Datasheet: https://wiki.u-blox.com/bin/view/Generic/Docs/DocumentSummary?index=8935; Min Operating Temp: -40°C; Part Number: UBXH18-0000573; Part Type: Thermistor; RefDes: RT; Tolerance: 5%; UBX Wiki: https://wiki.u-blox.com/bin/view/Operations/PartDetails?index=39142
SW1 = UBXH19-0000050: 2 WAY ON-ON SUB-MINIATURE SLIDE SWITCH SMD JS SERIES; Manufacturer: C&K Components; Database Table Name: Electromechanical - Switch; Editor: andre.pick; Env: ROHS; Mfg P/N Released: Yes; Mfg P/N Split: 100; Mfg P/N Status: Active; Perferred: PREF; Permitted Use: Support Products; Released by: martin.pilik; Component Kind: Standard; Library Name: UBX_Parts_DB_Lib.DbLib; Max Operating Temp: 85°C; Mfg P/N Datasheet: https://wiki.u-blox.com/bin/view/Generic/Docs/DocumentSummary?index=16625; Min Operating Temp: -40°C; Part Number: UBXH19-0000050; Part Type: Switch; RefDes: S; Release Data: 2022-06-09T16:59:15; UBX Wiki: https://wiki.u-blox.com/bin/view/Operations/PartDetails?index=36899
TP1-TP9 TP125 TP126 = TP10R: Testpoint, Round 1.0mm Dia; Added By: Jeremy D; Database Table Name: Testpoints & Pads; DNP: DNP; Height: 0; Part Number: TP10R; Size: 1.0mm; Checked By: Paul B; Library Name: LEGACY_Rigado_AltiumLib.DbLib
  TP1: Added Date: 2015-02-01; Checked Date: 2015-02-05; Component Kind: Standard
  TP2-TP9 TP125 TP126: Added Date: 2/1/2015; Checked Date: 2/5/2015
U2 = UBXH12-0000459: LOW DROPOUT REGULATOR ON SEMICONDUCTOR NCP691MN33T2G 6DFN 3.3V 6V 1A; Database Table Name: IC - Regulator; Editor: hakan.ekfors; Env: ROHS; Mfg P/N Released: Yes; Mfg P/N Split: 100; Mfg P/N Status: Active; Perferred: PREF; Permitted Use: Volume Products; Released by: markus.wejrot; Component Kind: Standard; Library Name: UBX_Parts_DB_Lib.DbLib; Manufacturer: ON Semiconductor; Manufacturer P/N: NCP691MN33T2G; Max Current: 1A; Max Operating Temp: 125°C; Max Voltage: 6V; Mfg P/N Datasheet: https://wiki.u-blox.com/bin/view/Generic/Docs/DocumentSummary?index=35425; Min Operating Temp: -40°C; Part Number: UBXH12-0000459; RefDes: U; Release Data: 2021-08-23 15:14:48.502500; UBX Wiki: https://wiki.u-blox.com/bin/view/Operations/PartDetails?index=35423; Value: 3.3V
U3 = TPS62130ARGTT: Integrated Circuit; Manufacturer_Name: Texas Instruments; Arrow Part Number: TPS62130ARGTT; Arrow Price/Stock: https://www.arrow.com/en/products/tps62130argtt/texas-instruments?region=nac; Component Kind: Standard; Datasheet Link: http://www.ti.com/lit/gpn/tps62130a; Height: 1mm; Manufacturer_Part_Number: TPS62130ARGTT; Mouser Part Number: 595-TPS62130ARGTT; Mouser Price/Stock: https://www.mouser.co.uk/ProductDetail/Texas-Instruments/TPS62130ARGTT?qs=iIeLWXrA%252B1WkTqP1kzAmnQ%3D%3D
U4 = UBXH05-0000185: BQ24230 BATTERY CHARGER LI-ION USB 100-500MA 4.2V FLOAT VQFN-16 BQ24230RGTR; Manufacturer: Texas Instruments; Body Size: 3.3mm x 3.3mm; Database Table Name: IC - Digital/Mixed Signal ASIC; Editor: eric.moog; Env: ROHS; Item Phase: Unreleased; Mfg P/N Released: No; Mfg P/N Split: 100; Mfg P/N Status: Active; Perferred: PREF; Permitted Use: Support Products; Single Source: Yes; SMT Pitch: 0.5mm; UBX Code: None; Voltage: 5-6.5V; Component Kind: Standard; Height: 1mm; Library Name: UBX_Parts_DB_Lib.DbLib; Manufacturer 1: Texas Instruments; Manufacturer 2: None; Manufacturer P/N: BQ24230RGTR; Manufacturer Part Number 1: bq24230; Manufacturer Part Number 2: None; Max Operating Temp: 125°C; Mfg P/N Datasheet: https://wiki.u-blox.com/bin/view/Generic/Docs/DocumentSummary?index=39076; MFG Package: VQFN-16; Min Operating Temp: -40°C; Part Number: UBXH05-0000185; Part Type: Digital/Mixed Signal ASIC; Pins: 16; RefDes: U; Revision: None; Size: Other; Supplier 1: Digi-key; Supplier 2: None; Supplier Part Number 1: 296-23937-2-ND; Supplier Part Number 2: None; UBX Wiki: https://wiki.u-blox.com/bin/view/Operations/PartDetails?index=39077; Use in new designs: Yes; Value: BQ24230RGTR
